# Altium schematic sheet ClimaSens-Sensor.SchDoc(ClimaSens-Sensor)
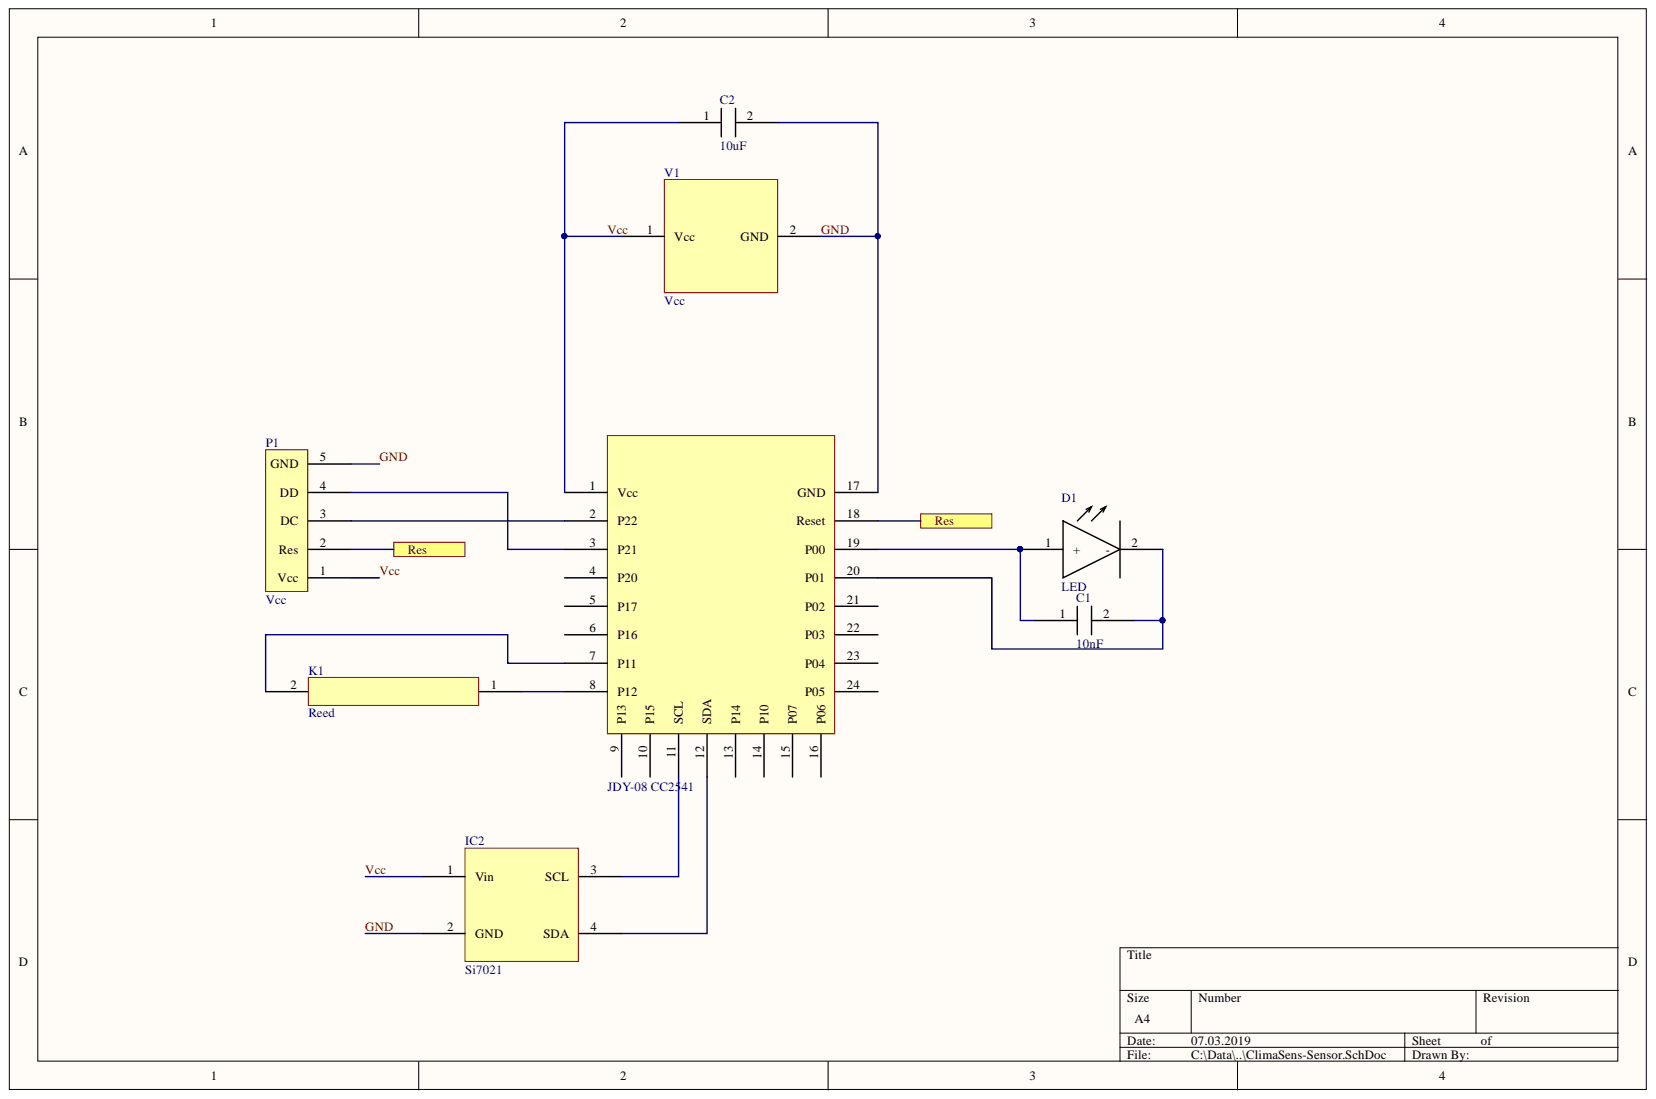
[diagram p0: ClimaSens-Sensor.SchDoc]

## Parts
C1  10nF  [0805]
C2  10uF  [0805]
D1  LED  [LED 3mm]
IC2  Si7021  [Si7021]
K1  Reed  [Reed]
P1  Vcc  [ProgramPort]
V1  Vcc  [Battery-Clip]
  JDY-08 CC2541  [JDY-08 CC2541]

## Ports
Res

## Nets
Net_2: P1.3(DC) .2(P22)
Net_3: P1.4(DD) .3(P21)
Net_4: .4(P20)
Net_5: .5(P17)
Net_6: .6(P16)
Net_7: K1.2 .7(P11)
Net_8: K1.1 .8(P12)
Net_9: .9(P13)
Net_10: .10(P15)
Net_11: IC2.3(SCL) .11(SCL)
Net_12: IC2.4(SDA) .12(SDA)
Net_13: .13(P14)
Net_14: .14(P10)
Net_15: .15(P07)
Net_16: .16(P06)
Net_19: C1.1 D1.1 .19(P00)
Net_20: C1.2 D1.2 .20(P01)
Net_21: .21(P02)
Net_22: .22(P03)
Net_23: .23(P04)
Net_24: .24(P05)
GND: C2.2 IC2.2(GND) P1.5(GND) V1.2 .17(GND)
Net_18: P1.2(Res) .18(Reset) ; port Res
Vcc: C2.1 IC2.1(Vin) P1.1 V1.1 .1(Vcc)

## Library data of the parts
C1 C2 = Capacitator: Component Kind: Standard; Library Name: ClimaSens-Lib.SchLib
P1 = ProgramPort: Component Kind: Standard; Library Name: ClimaSens-Lib.SchLib
V1 = Battery Clip: Component Kind: Standard; Library Name: ClimaSens-Lib.SchLib
D1 = LED: Component Kind: Standard; Library Name: ClimaSens-Lib.SchLib
IC2 = Si7021: Component Kind: Standard; Library Name: ClimaSens-Lib.SchLib
K1 = Reed: Component Kind: Standard; Library Name: ClimaSens-Lib.SchLib
 = JDY-08 CC2541: Component Kind: Standard; Library Name: ClimaSens-Lib.SchLib
